# Altium schematic sheet PS_Power.SchDoc
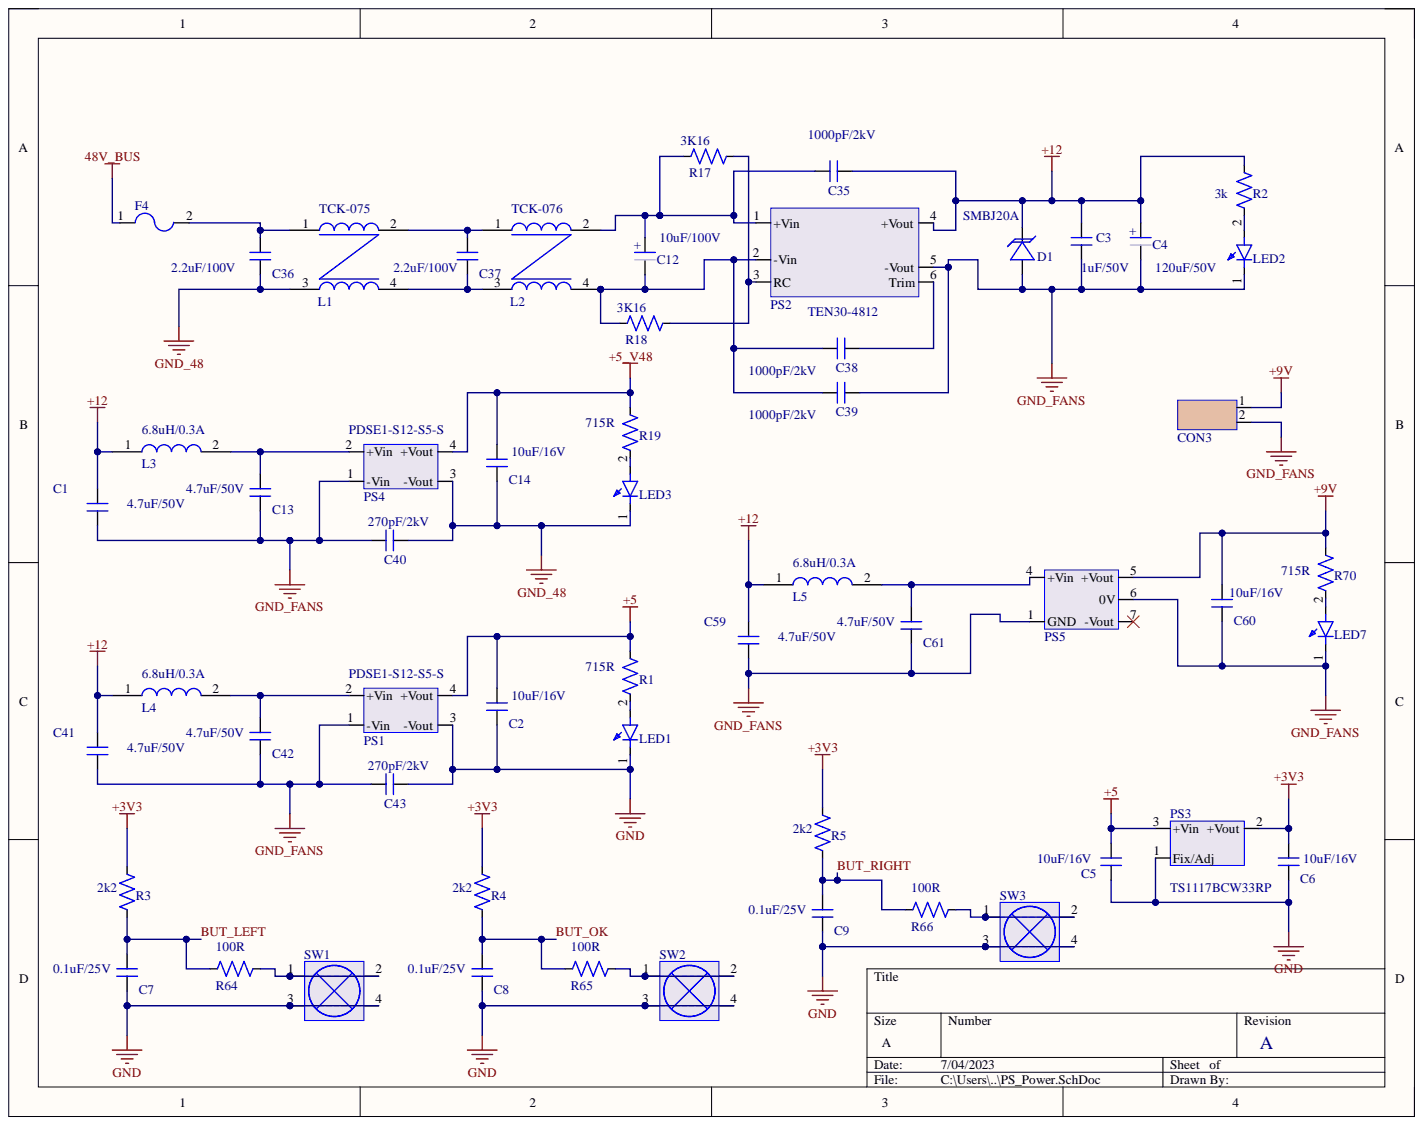
[diagram p0: PS_Power.SchDoc]

## Parts
C1  4.7uF/50V  [C1206]
C2  10uF/16V  [C0805]
C3  1uF/50V  [C0805]
C4  120uF/50V  [Cap_THT_O8mm]
C5  10uF/16V  [C0805]
C6  10uF/16V  [C0805]
C7  0.1uF/25V  [C0402]
C8  0.1uF/25V  [C0402]
C9  0.1uF/25V  [C0402]
C12  10uF/100V  [Cap_THT_O6mm-Lead2.5mm]
C13  4.7uF/50V  [C1206]
C14  10uF/16V  [C0805]
C35  1000pF/2kV  [C1808]
C36  2.2uF/100V  [C1812]
C37  2.2uF/100V  [C1812]
C38  1000pF/2kV  [C1808]
C39  1000pF/2kV  [C1808]
C40  270pF/2kV  [C1206]
C41  4.7uF/50V  [C1206]
C42  4.7uF/50V  [C1206]
C43  270pF/2kV  [C1206]
C59  4.7uF/50V  [C1206]
C60  10uF/16V  [C0805]
C61  4.7uF/50V  [C1206]
CON3  22-28-4024  [Con1x02_2.54]  (value not drawn)
D1  SMBJ20A  [DO-214AA-2]
F4  (no value)  [fuse 1.6A 250V - duplicate]
L1  TCK-075  [TCK-075]
L2  TCK-076  [TCK-075]
L3  6.8uH/0.3A  [L3]
L4  6.8uH/0.3A  [L3]
L5  6.8uH/0.3A  [L0603]
LED1  150080GS75000  [L0805]  (value not drawn)
LED2  150080GS75000  [L0805]  (value not drawn)
LED3  150080GS75000  [L0805]  (value not drawn)
LED7  150080GS75000  [L0805]  (value not drawn)
PS1  PDSE1-S12-S5-S  [SIP-4]
PS2  TEN30-4812  [2''_x_1'']
PS3  TS1117BCW33RP  [SOT-223-3]
PS4  PDSE1-S12-S5-S  [SIP-4]
PS5  PQP3-D12-D9-D  [DIP-5]  (value not drawn)
R1  715R  [R0603]
R2  3k  [R0805]
R3  2k2  [R0402]
R4  2k2  [R0402]
R5  2k2  [R0402]
R17  3K16  [R0402]
R18  3K16  [R0402]
R19  715R  [R0603]
R64  100R  [R0402]
R65  100R  [R0402]
R66  100R  [R0402]
R70  715R  [R0603]
SW1  (no value)  [12mm_SMD]
SW2  (no value)  [12mm_SMD]
SW3  (no value)  [12mm_SMD]

## Nets
48V_BUS: F4.1
+3V3: C6.1 PS3.2 R3.2 R4.2 R5.2
+5: C2.1 C5.1 PS1.4(+Vout) PS3.3 R1.2
+5_V48: C14.1 PS4.4(+Vout) R19.2
+9V: C60.1 CON3.1 PS5.5(+Vout) R70.2
+12: C1.1 C3.1 C4.1 C35.2 C41.1 C59.1 D1.1 L3.1 L4.1 L5.1 PS2.4(+Vout) R2.2
GND: C2.2 C5.2 C6.2 C7.2 C8.2 C9.2 C43.2 LED1.1 PS1.3(-Vout) PS3.1 SW1.3 SW1.4 SW2.3 SW2.4 SW3.3 SW3.4
GND_48: C14.2 C36.2 C40.2 L1.3 LED3.1 PS4.3(-Vout)
GND_FANS: C1.2 C3.2 C4.2 C13.2 C39.2 C40.1 C41.2 C42.2 C43.1 C59.2 C60.2 C61.2 CON3.2 D1.2 LED2.1 LED7.1 PS1.1(-Vin) PS2.5(-Vout) PS4.1(-Vin) PS5.1(GND) PS5.6(0V)
BUT_LEFT: C7.1 R3.1 R64.1
BUT_OK: C8.1 R4.1 R65.1
BUT_RIGHT: C9.1 R5.1 R66.1
NetC12_1: C12.1 C35.1 L2.2 PS2.1(+Vin) R17.1
NetC12_2: C12.2 C38.1 C39.1 L2.4 PS2.2(-Vin) R18.2
NetC13_1: C13.1 L3.2 PS4.2(+Vin)
NetC36_1: C36.1 F4.2 L1.1
NetC37_1: C37.1 L1.2 L2.1
NetC37_2: C37.2 L1.4 L2.3
NetC38_2: C38.2 PS2.6(Trim)
NetC42_1: C42.1 L4.2 PS1.2(+Vin)
NetC61_1: C61.1 L5.2 PS5.4(+Vin)
NetLED1_2: LED1.2 R1.1
NetLED2_2: LED2.2 R2.1
NetLED3_2: LED3.2 R19.1
NetLED7_2: LED7.2 R70.1
NetPS2_3: PS2.3(RC) R17.2 R18.1
NetR64_2: R64.2 SW1.1 SW1.2
NetR65_2: R65.2 SW2.1 SW2.2
NetR66_2: R66.2 SW3.1 SW3.2

Not connected: PS5 7(-Vout)

## Library data of the parts
C1 C13 C41 C42 C59 C61 = CL31B475KBHNFNE: CAP CER 4.7UF 50V X7R 1206; Manufacturer: Samsung; Database Table Name: components; Tolerance: 10%; Capacitance: 4.7uF; Case Code (Imperial): 1206; Case Code (Metric): 3216; Case/Package: 1206; Category: Ceramic Capacitors; Component Kind: Standard; ComponentLink1Description: https://datasheet.ciiva.com/25726/cl31b475kbh; ComponentLink1URL: https://datasheet.ciiva.com/25726/cl31b475kbh; Construction: Flat; Depth: 1.6mm; Dielectric: X7R; Height: 1.6mm; Length: 3.2mm; Library Name: Resistors-Capacitors.DbLib; Manufacturer Part Number: CL31B475KBHNFNE; Max Operating Temperature: 125°C; Min Operating Temperature: -55°C; Mount: Surface Mount; Package Quantity: 1; Packaging: Tape and Reel; Part Number: CL31B475KBHNFNE; Pricing 1: 1=0,30000, 10=0,13500, 50=0,13500, 100=0,1150; Radiation Hardening: No; RoHS: Yes; RoHS Compliant: Yes; Series: CL; Stock 1: 112063; Supplier 1: Mouser; Supplier Part Number 1: 187-CL31B475KBHNFNE; Thickness: 0.071inch; Voltage Rating: 50V; Width: 0.063inch
C2 C5 C6 C14 C60 = CL21A106KOQNNNE: Multilayer Ceramic Capacitors MLCC - SMD/SMT; Manufacturer: Samsung; Capacitance: 10uF; Database Table Name: components; Dielectric: X7R; Tolerance: 10%; Voltage: 16V; Case Code (Imperial): 0805; Case Code (Metric): 2012; Case/Package: 0805; Category: Ceramic Capacitors; Component Kind: Standard; Construction: Flat; Depth: 1.25mm; Height: 1.25mm; Lead Free: Lead Free; Length: 2mm; Library Name: Resistors-Capacitors.DbLib; Manufacturer Part Number: CL21A106KOQNNNE; Max Operating Temperature: 85°C; Min Operating Temperature: -55°C; Mount: Surface Mount; Package Quantity: 1; Packaging: Tape and Reel; Part Number: CL21A106KOQNNNE; Pricing 1: 1=0,10000, 10=0,04100, 50=0,04100, 100=0,0330; Radiation Hardening: No; RoHS: Yes; RoHS Compliant: Yes; Series: CL; Stock 1: 580556; Supplier 1: Mouser; Supplier Part Number 1: 187-CL21A106KOQNNNE; Thickness: 0.055inch; Voltage Rating: 16V; Voltage Rating (DC): 16V; Width: 0.049inch
C3 = CL21B105KBFNNNE: CAP CER 1UF 50V X7R 0805; Manufacturer: Samsung; Database Table Name: components; Tolerance: 10%; Capacitance: 1uF; Case Code (Imperial): 0805; Case Code (Metric): 2012; Case/Package: 0805; Category: Ceramic Capacitors; Component Kind: Standard; Library Name: Resistors-Capacitors.DbLib; Manufacturer Part Number: CL21B105KBFNNNE; Max Operating Temperature: 125°C; Min Operating Temperature: -55°C; Mount: Surface Mount; Package Quantity: 1; Packaging: Tape and Reel; Part Number: CL21B105KBFNNNE; Pricing 1: 1=0,12000, 10=0,08600, 50=0,08600, 100=0,0410; RoHS: Yes; Stock 1: 18533; Supplier 1: Mouser; Supplier Part Number 1: 187-CL21B105KBFNNNE; Voltage Rating: 50V
C4 = 860010674015: Aluminium Electrolytic Capacitor, Wcap-Atg8 S; Manufacturer: Wurth Electronics; Database Table Name: components; Case/Package: Radial; Category: Aluminum Electrolytic Capacitors; Component Kind: Standard; Library Name: Resistors-Capacitors.DbLib; Manufacturer Part Number: 860010674015; Mount: Through Hole; Part Number: 860010674015; Pricing 1: 1=0,31000, 10=0,30800, 50=0,26400, 100=0,2300; RoHS: Yes; Stock 1: 1491; Supplier 1: Mouser; Supplier Part Number 1: 710-860010674015
C7-C9 = C0402C104K3RACTU: Multilayer Ceramic 25V 0.1uF X7R 0402 10%; Manufacturer: Yageo; Database Table Name: components; Case/Package: 0402; Component Kind: Standard; Library Name: Resistors-Capacitors.DbLib; Part Number: C0402C104K3RACTU; Supplier 1: Mouser; Supplier Part Number 1: 80-C0402C104K3R
C12 = ESH106M100AE3AA: Cap Aluminum 10uF 100V 20% (6 X 11mm) Radial; Manufacturer: KEMET; Database Table Name: components; Case/Package: Radial; Category: Aluminum Electrolytic Capacitors; Component Kind: Standard; Library Name: Resistors-Capacitors.DbLib; Manufacturer Part Number: ESH106M100AE3AA; Mount: Through Hole,PCB; Part Number: ESH106M100AE3AA; Pricing 1: 1=0,26000, 10=0,18100, 50=0,12100, 100=0,1030; RoHS: Yes; Stock 1: 18650; Supplier 1: Mouser; Supplier Part Number 1: 80-ESH106M100AE3AA
C35 C38 C39 = GR442QR73D102KW01L: Cap Ceramic 0.001uF 2000V X7R 10% SMD 1808 12; Manufacturer: Murata; Capacitance: 1nF; Database Table Name: components; Voltage: 2kV; Case Code (Imperial): 1808; Case Code (Metric): 4520; Case/Package: 1808; Category: Ceramic Capacitors; Component Kind: Standard; ComponentLink1Description: https://datasheet.ciiva.com/29540/product-295; ComponentLink1URL: https://datasheet.ciiva.com/29540/product-295; ComponentLink2Description: https://datasheet.ciiva.com/11789/0900766b80e; ComponentLink2URL: https://datasheet.ciiva.com/11789/0900766b80e; ComponentLink3Description: https://datasheet.ciiva.com/18183/getdatashee; ComponentLink3URL: https://datasheet.ciiva.com/18183/getdatashee; ComponentLink4Description: https://datasheet.ciiva.com/29540/partnumberi; ComponentLink4URL: https://datasheet.ciiva.com/29540/partnumberi; ComponentLink5Description: https://datasheet.ciiva.com/16497/product-164; ComponentLink5URL: https://datasheet.ciiva.com/16497/product-164; Construction: Flat; Depth: 2mm; Dielectric: X7R; Height: 1.5mm; Lead Free: Lead Free; Length: 4.5mm; Library Name: Resistors-Capacitors.DbLib; Manufacturer Part Number: GR442QR73D102KW01L; Max Operating Temperature: 125°C; Min Operating Temperature: -55°C; Mount: Surface Mount; Package Quantity: 1; Packaging: Tape and Reel; Part Number: GR442QR73D102KW01L; Pricing 1: 1=0,44000, 10=0,27800, 50=0,27800, 100=0,2060; Radiation Hardening: No; Reach SVHC Compliant: Yes; RoHS: Yes; RoHS Compliant: Yes; Series: GR4; Stock 1: 172848; Supplier 1: Mouser; Supplier Part Number 1: 81-GR442QR73D102KW01; Termination: SMD/SMT; Thickness: 0.059inch; Tolerance: 10%; Voltage Rating: 2kV; Voltage Rating (DC): 2kV; Width: 0.079inch
C36 C37 = C4532X7R2A225K230KA: C Series 1812 2.2 uF 100 V ±10 % Tolerance X7; Manufacturer: TDK; Capacitance: 2.2uF; Database Table Name: components; Dielectric: X7R; Tolerance: 10%; Voltage: 100V; Case Code (Imperial): 1812; Case Code (Metric): 4532; Case/Package: 1812; Category: Ceramic Capacitors; Component Kind: Standard; ComponentLink1Description: https://datasheet.ciiva.com/24216/c4532x7r2a2; ComponentLink1URL: https://datasheet.ciiva.com/24216/c4532x7r2a2; ComponentLink2Description: https://datasheet.ciiva.com/11788/0900766b80f; ComponentLink2URL: https://datasheet.ciiva.com/11788/0900766b80f; ComponentLink3Description: https://datasheet.ciiva.com/22725/1913358-227; ComponentLink3URL: https://datasheet.ciiva.com/22725/1913358-227; ComponentLink4Description: https://datasheet.ciiva.com/23803/1796654-238; ComponentLink4URL: https://datasheet.ciiva.com/23803/1796654-238; Construction: Flat; Depth: 3.2mm; Height: 2.3mm; Lead Free: Lead Free; Length: 4.5mm; Library Name: Resistors-Capacitors.DbLib; Manufacturer Part Number: C4532X7R2A225K230KA; Max Operating Temperature: 125°C; Min Operating Temperature: -55°C; Mount: Surface Mount; Number of Pins: 2; Package Quantity: 500; Packaging: Tape and Reel; Part Number: C4532X7R2A225K230KA; Pricing 1: 1=0,86000, 10=0,54600, 50=0,54600, 100=0,4700; Radiation Hardening: No; Reach SVHC Compliant: Yes; Resistor Type: Ceramic; RoHS: Yes; RoHS Compliant: Yes; Series: C; Stock 1: 28251; Supplier 1: Mouser; Supplier Part Number 1: 810-C4532X7R2A225K; Termination: SMD/SMT; Thickness: 0.098inch; Voltage Rating: 100V; Voltage Rating (DC): 100V; Weight: 0.000952oz; Width: 3.2mm
C40 C43 = 1206GC271KAT1A: 1206 HV 270pF Ceramic Multilayer Cap; 2000Vdc; Manufacturer: Kyocera AVX; Database Table Name: components; Dielectric: X7R; Temperature Coefficient: 30ppm/°C; Tolerance: 10%; Voltage: 2kV; Capacitance: 270pF; Case Code (Imperial): 1206; Case Code (Metric): 3216; Case/Package: 1206; Category: Ceramic Capacitors; Component Kind: Standard; ComponentLink1Description: https://datasheet.ciiva.com/11787/0900766b80d; ComponentLink1URL: https://datasheet.ciiva.com/11787/0900766b80d; ComponentLink2Description: https://datasheet.ciiva.com/215/aphvc-215777.; ComponentLink2URL: https://datasheet.ciiva.com/215/aphvc-215777.; ComponentLink3Description: https://datasheet.ciiva.com/11541/aphvc-11541; ComponentLink3URL: https://datasheet.ciiva.com/11541/aphvc-11541; ComponentLink4Description: https://datasheet.ciiva.com/13190/aphvc-13190; ComponentLink4URL: https://datasheet.ciiva.com/13190/aphvc-13190; Construction: Flat; Depth: 1.6mm; Dielectric Material: Ceramic; Height: 1.52mm; Lead Free: Lead Free; Length: 3.2mm; Library Name: Resistors-Capacitors.DbLib; Manufacturer Part Number: 1206GC271KAT1A; Max Operating Temperature: 125°C; Min Operating Temperature: -55°C; Mount: Surface Mount; Number of Pins: 2; Package Quantity: 2000; Packaging: Tape and Reel; Part Number: 1206GC271KAT1A; Pricing 1: 1=0,74000, 10=0,55200, 50=0,55200, 100=0,3780; Radiation Hardening: No; Reach SVHC Compliant: Yes; RoHS: Yes; RoHS Compliant: Yes; Series: -; Stock 1: 4864; Supplier 1: Mouser; Supplier Part Number 1: 581-1206GC271KAT1A; Termination: SMD/SMT; Thickness: 0.06inch; Voltage Rating: 2kV; Voltage Rating (DC): 2kV; Width: 0.063inch
CON3 = 22-28-4024: Breakaway Header; KK 254; Vertical; 2 Circuit; Manufacturer: Molex; Database Table Name: connectors; Category: Headers and Wire Housings; Component Kind: Standard; ComponentLink1Description: https://datasheet.ciiva.com/10088/0022284024-; ComponentLink1URL: https://datasheet.ciiva.com/10088/0022284024-; ComponentLink2Description: https://datasheet.ciiva.com/5153/0022284024-p; ComponentLink2URL: https://datasheet.ciiva.com/5153/0022284024-p; ComponentLink3Description: https://datasheet.ciiva.com/30120/63280022284; ComponentLink3URL: https://datasheet.ciiva.com/30120/63280022284; ComponentLink4Description: https://datasheet.ciiva.com/18451/70090858-18; ComponentLink4URL: https://datasheet.ciiva.com/18451/70090858-18; ComponentLink5Description: https://datasheet.ciiva.com/1433/1856632-1433; ComponentLink5URL: https://datasheet.ciiva.com/1433/1856632-1433; Library Name: Connectors.DbLib; Manufacturer Part Number: 22-28-4024; Part Number: 22-28-4024; Pricing 1: 1=0,22000, 10=0,17700, 50=0,17700, 100=0,1270; RoHS: Yes; Stock 1: 11115; Supplier 1: Mouser; Supplier Part Number 1: 538-22-28-4024
D1 = SMBJ20A: Diode TVS Single Uni-Dir 20V 600W 2-Pin SMB; Manufacturer: Littelfuse; Breakdown Voltage: 22.2V; Clamping Voltage: 32.4V; Database Table Name: components; Diode Type: ZENER; Direction: Unidirectional; Leakage Current: 1uA; Max Breakdown Voltage: 24.5V; Max Surge Current: 18.6A; Number of Channels: 1; Power Line Protection: No; Test Current: 1mA; Case/Package: DO-214AA; Category: TVS Diodes; Clamping Voltage-Max: 32.4V; Component Kind: Standard; ComponentLink1Description: https://datasheet.ciiva.com/46381/607623-4638; ComponentLink1URL: https://datasheet.ciiva.com/46381/607623-4638; ComponentLink2Description: https://datasheet.ciiva.com/331/littelfuse-tv; ComponentLink2URL: https://datasheet.ciiva.com/331/littelfuse-tv; ComponentLink3Description: https://datasheet.ciiva.com/16391/littelfuse-; ComponentLink3URL: https://datasheet.ciiva.com/16391/littelfuse-; ComponentLink4Description: https://datasheet.ciiva.com/331/littelfuse-tv; ComponentLink4URL: https://datasheet.ciiva.com/331/littelfuse-tv; ComponentLink5Description: https://datasheet.ciiva.com/331/littelfuse-tv; ComponentLink5URL: https://datasheet.ciiva.com/331/littelfuse-tv; ComponentLink6Description: https://datasheet.ciiva.com/4509/littelfuse-t; ComponentLink6URL: https://datasheet.ciiva.com/4509/littelfuse-t; Height: 2.44mm; Lead Free: Lead Free; Length: 5.59mm; Library Name: Diodes.DbLib; Manufacturer Part Number: SMBJ20A; Max Operating Temperature: 150°C; Max Power Dissipation: 600W; Min Breakdown Voltage: 22.2V; Min Operating Temperature: -55°C; Mount: Surface Mount; Number of Elements: 1; Number of Pins: 2; Number of Unidirectional Channels: 1; Operating Supply Voltage: 20V; Package Quantity: 3000; Packaging: Tape and Reel; Part Number: SMBJ20A; Peak Pulse Current: 18.6A; Peak Pulse Power: 600W; Polarity: Unidirectional; Power Dissipation: 600W; Pricing 1: 1=0,48510, 10=0,37210, 25=0,36840, 100=0,2633; Radiation Hardening: No; REACH SVHC: No SVHC; Resistor Type: Zener; Reverse Breakdown Voltage: 22.2V; Reverse Standoff Voltage: 20V; RoHS: Yes; RoHS Compliant: Yes; Series: SMBJ; Stock 1: 6334; Supplier 1: Mouser; Supplier Part Number 1: 821-SMBJ20A; Termination: SMD/SMT; Voltage Rating (DC): 20V; Width: 3.94mm
F4 = 3403.0168.11: Fuse Chip Slow Blow Acting 1.6A 250V SMD Sold; Manufacturer: Schurter; Database Table Name: components; Case/Package: SMD/SMT; Category: Fuses; Component Kind: Standard; Library Name: Supplies.DbLib; Manufacturer Part Number: 3403.0168.11; Mount: Surface Mount,PCB; Number of Pins: 2; Part Number: 3403.0168.11; Pricing 1: 1=0,69000, 10=0,69000, 50=0,62200, 100=0,5670; RoHS: Yes; Stock 1: 1214; Supplier 1: Mouser; Supplier Part Number 1: 693-3403.0168.11; Weight: 0.008113oz
L1 = TCK-075: Common Mode Chokes Dual 33.3uH 100kHz 4A 0.00; Manufacturer: Traco Power; Database Table Name: components; Category: Common Mode Chokes; Component Kind: Standard; Library Name: Circuit_Protection.DbLib; Manufacturer Part Number: TCK-075; Max Operating Temperature: 105°C; Min Operating Temperature: -40°C; Mount: Through Hole; Part Number: TCK-075; Pricing 1: 1=6,29000, 10=5,71000, 50=5,14000, 100=4,1900; RoHS: Yes; Stock 1: 90; Supplier 1: Mouser; Supplier Part Number 1: 495-TCK-075
L2 = TCK-076: COMMON MODE CHOKE, 55UH, 5.7A; Product Range:; Manufacturer: Traco Power; Current Rating: 4A; Database Table Name: components; Inductance: 55.23uH; Number of Lines: 2; Category: Common Mode Chokes; Component Kind: Standard; Library Name: Circuit_Protection.DbLib; Manufacturer Part Number: TCK-076; Max Current Rating: 5.7A; Max Operating Temperature: 105°C; Min Operating Temperature: -40°C; Mount: Through Hole; Part Number: TCK-076; Pricing 1: 1=6,29000, 10=5,71000, 50=4,48000, 100=4,4800; RoHS: Yes; Stock 1: 84; Supplier 1: Mouser; Supplier Part Number 1: 495-TCK-076
L3-L5 = MLZ1608M6R8WT000: 1.6mm x 0.8mm x 0.8mm, -55 to +125 degC, 100m; Manufacturer: TDK; Database Table Name: components; Category: Fixed Inductors; Component Kind: Standard; Library Name: Resistors-Capacitors.DbLib; Manufacturer Part Number: MLZ1608M6R8WT000; Part Number: MLZ1608M6R8WT000; Pricing 1: 1=0,14000, 10=0,10300, 50=0,10300, 100=0,0820; RoHS: Yes; Stock 1: 398; Supplier 1: Mouser; Supplier Part Number 1: 810-MLZ1608M6R8WT000
LED1-LED3 LED7 = 150080GS75000: Led, Green, 520 Nm, 3.2 V, 30 Ma, 450 Mcd Roh; Manufacturer: Wurth Electronics; Database Table Name: hmi; Category: LEDs; Component Kind: Standard; Library Name: HMI.DbLib; Manufacturer Part Number: 150080GS75000; Part Number: 150080GS75000; Pricing 1: 1=0,19000, 10=0,18700, 50=0,17600, 100=0,1510; RoHS: Yes; Stock 1: 29367; Supplier 1: Mouser; Supplier Part Number 1: 710-150080GS75000
PS1 PS4 = PDSE1-S12-S5-S: 5 Vdc, 0.2 A, 1 W, 10.8~13.2 Vdc Input Range; Manufacturer: CUI; Database Table Name: components; Category: Board Mount Modules; Component Kind: Standard; Library Name: Supplies.DbLib; Manufacturer Part Number: PDSE1-S12-S5-S; Part Number: PDSE1-S12-S5-S; Pricing 1: 1=2,57000, 10=2,51000, 50=2,29000, 100=2,2900; RoHS: Yes; Stock 1: 231; Supplier 1: Mouser; Supplier Part Number 1: 490-PDSE1-S12-S5-S
PS2 = TEN30-4812: Module DC-DC 48VIN 1-OUT 12V 25A 30W 6-Pin; Manufacturer: Traco Power; Approvals: VDE,TUV,cURus,CQC,PSE,KC; Body Material: Ceramic; Current - Output 1: 2.5A; Current Rating: 1.6A; Database Table Name: components; Efficiency: 91%; Fuse Size: 10.1 X 3mm; Fuse Type: Board Mount (Cartridge Style Excluded); Isolation: Isolated; Isolation Voltage: 1.5kV; Max Input Voltage: 75V; Max Power Dissipation: 1W; Max Voltage Rating (AC): 250V; Max Voltage Rating (DC): 125V; Min Input Voltage: 36V; Nominal Input Voltage: 48V; Number of Outputs: 1; Case/Package: Metal; Component Kind: Standard; ComponentLink1Description: https://datasheet.ciiva.com/41865/1648785-418; ComponentLink1URL: https://datasheet.ciiva.com/41865/1648785-418; ComponentLink2Description: https://datasheet.ciiva.com/26336/157312-da-0; ComponentLink2URL: https://datasheet.ciiva.com/26336/157312-da-0; ComponentLink3Description: https://datasheet.ciiva.com/8006/1763620-8006; ComponentLink3URL: https://datasheet.ciiva.com/8006/1763620-8006; ComponentLink4Description: https://datasheet.ciiva.com/302/typ-umt-250-3; ComponentLink4URL: https://datasheet.ciiva.com/302/typ-umt-250-3; ComponentLink5Description: https://datasheet.ciiva.com/8266/typ-umt-250-; ComponentLink5URL: https://datasheet.ciiva.com/8266/typ-umt-250-; Contact Plating: Tin; Depth: 50.8mm; Height: 10.2mm; Housing Material: Ceramic; Lead Free: Lead Free; Length: 50mm; Library Name: Supplies.DbLib; Manufacturer Part Number: TEN30-4812; Max Input Voltage (DC): 75V; Max Operating Temperature: 85°C; Max Output Current: 2.5A; Max Output Power: 30W; Min Input Voltage (DC): 36V; Min Operating Temperature: -40°C; Mount: Through Hole; Nominal Output Voltage: 12V; Number of Pins: 6; Output Current: 25A; Output Voltage: 12V; Package Quantity: 100; Packaging: Bulk; Part Number: TEN30-4812; Power Dissipation: 1W; Pricing 1: 1=68,12000, 10=62,01000, 50=53,15000, 100=53,; Radiation Hardening: No; Reach SVHC Compliant: Yes; RoHS: Yes; RoHS Compliant: Yes; Series: TEN 30; Stock 1: 9; Supplier 1: Mouser; Supplier Part Number 1: 495-TEN30-4812; Termination: SMD/SMT; Voltage - Output 1: 12V; Voltage Rating: 250V; Voltage Rating (AC): 250V; Voltage Rating (DC): 125V; Weight: 31g; Width: 25.4mm
PS3 = TS1117BCW33RP: LDO Regulator Pos 3.3V 1A 4-Pin(3+Tab) SOT-22; Manufacturer: Taiwan Semiconductor; Accuracy: 2%; Amplifier Type: GENERAL PURPOSE; Common Mode Rejection Ratio: 50dB; Database Table Name: components; Gain Bandwidth Product: 1.5MHz; Input Bias Current: 14nA; Input Offset Voltage (Vos): 1mV; Max Input Voltage: 15V; Max Output Current: 800mA; Max Power Dissipation: 450mW; Max Reset Threshold Voltage: 1.295V; Max Supply Current: 900uA; Max Supply Voltage: 5V; Min Reset Threshold Voltage: 1.245V; Min Supply Voltage: 1.8V; Nominal Supply Current: 103uA; Number of Amplifiers: 1; Number of Voltages Monitored: 2; Operating Supply Current: 116uA; Operating Supply Voltage: 3V; Output Current per Channel: 100mA; Output Type: Fixed; Polarity: Positive; Power Dissipation: 15W; Power Supply Rejection Ratio (PSRR): 75dB; Quiescent Current: 10mA; Reference Voltage: 1.275V; Slew Rate: 0.42V/µs; Threshold Voltage: 1.27V; Voltage Gain: 113dB; Watchdog Timer: No; Case/Package: SOT-223; Category: Uncategorized; Component Kind: Standard; ComponentLink1Description: http://uk.rs-online.com/webdocs/1405/0900766b; ComponentLink1URL: http://uk.rs-online.com/webdocs/1405/0900766b; ComponentLink2Description: http://components.arrow.com/part/dataview/459; ComponentLink2URL: http://components.arrow.com/part/dataview/459; ComponentLink3Description: https://datasheet.ciiva.com/5704/786773-57042; ComponentLink3URL: https://datasheet.ciiva.com/5704/786773-57042; ComponentLink4Description: https://datasheet.ciiva.com/6627/lmv981-n-662; ComponentLink4URL: https://datasheet.ciiva.com/6627/lmv981-n-662; ComponentLink5Description: https://datasheet.ciiva.com/6627/lmv981-n-662; ComponentLink5URL: https://datasheet.ciiva.com/6627/lmv981-n-662; ComponentLink6Description: https://datasheet.ciiva.com/6627/lmv981-n-662; ComponentLink6URL: https://datasheet.ciiva.com/6627/lmv981-n-662; ComponentLink7Description: https://datasheet.ciiva.com/1179/mc34161-d-11; ComponentLink7URL: https://datasheet.ciiva.com/1179/mc34161-d-11; ComponentLink8Description: https://datasheet.ciiva.com/1970/mc34161-d-19; ComponentLink8URL: https://datasheet.ciiva.com/1970/mc34161-d-19; ComponentLink9Description: https://datasheet.ciiva.com/3306/mc34161-d-33; ComponentLink9URL: https://datasheet.ciiva.com/3306/mc34161-d-33; ComponentLink10Description: https://datasheet.ciiva.com/8132/mc34161-d-81; ComponentLink10URL: https://datasheet.ciiva.com/8132/mc34161-d-81; ComponentLink11Description: https://datasheet.ciiva.com/1970/mc34161-d-19; ComponentLink11URL: https://datasheet.ciiva.com/1970/mc34161-d-19; ComponentLink12Description: https://datasheet.ciiva.com/1970/mc34161-d-19; ComponentLink12URL: https://datasheet.ciiva.com/1970/mc34161-d-19; Halogen Free: Halogen Free; Height: 1.8mm; Lead Free: Lead Free; Length: 6.85mm; Library Name: ICs-smd.DbLib; Manufacturer Part Number: TS1117BCW33RP; Max Operating Temperature: 125°C; Min Operating Temperature: 0°C; Mount: Surface Mount; Number of Circuits: 1; Number of Elements: 1; Number of Outputs: 1; Output Current: 1A; Output Voltage: 3.3V; Output Voltage Accuracy: 2%; Package Quantity: 1000; Packaging: Tape and Reel; Part Number: TS1117BCW33RP; Power Rating: 15W; Radiation Hardening: No; Reach SVHC Compliant: Yes; RoHS: Yes; RoHS Compliant: Yes; Series: -; Supplier 1: Mouser; Supplier Part Number 1: 821-TS1117BCW33RPG; Technology: BICMOS; Type: Multi-Voltage Supervisor; Unity Gain Bandwidth Product: 1.5MHz; Width: 3.75mm
PS5 = PQP3-D12-D9-D: 9/-9 Vdc, 0.167/-0.167 A, 3 W, 9~18 Vdc Input; Manufacturer: CUI; Database Table Name: components; Category: Board Mount Modules; Component Kind: Standard; Library Name: Supplies.DbLib; Manufacturer Part Number: PQP3-D12-D9-D; Part Number: PQP3-D12-D9-D; Pricing 1: 1=9,27, 10=9,13, 50=8,44, 100=8,44, 1000=7,46; RoHS: Yes; Stock 1: 23; Supplier 1: Mouser; Supplier Part Number 1: 490-PQP3-D12-D9-D
R1 R19 R70 = RT0603FRE07715RL: Res Thin Film 0603 715 Ohm 1% 1/10W ±50ppm/°C; Manufacturer: Yageo; Database Table Name: components; Max Power Dissipation: 100mW; Voltage Rating: 75V; Voltage Rating (DC): 50V; Case Code (Imperial): 0603; Case Code (Metric): 1608; Case/Package: 0603; Category: Chip SMD Resistors; Component Kind: Standard; ComponentLink1Description: https://datasheet.ciiva.com/2559/pyu-rt-1-to-; ComponentLink1URL: https://datasheet.ciiva.com/2559/pyu-rt-1-to-; ComponentLink2Description: https://datasheet.ciiva.com/12702/pyu-rt-1-to; ComponentLink2URL: https://datasheet.ciiva.com/12702/pyu-rt-1-to; Contact Plating: Tin,Matte; Height: 0.022inch; Lead Free: Lead Free; Length: 0.063inch; Library Name: Resistors-Capacitors.DbLib; Manufacturer Part Number: RT0603FRE07715RL; Max Operating Temperature: 125°C; Min Operating Temperature: -55°C; Number of Terminations: 2; Package Quantity: 5000; Packaging: Tape and Reel; Part Number: RT0603FRE07715RL; Power Rating: 100mW; Pricing 1: 1=0,10000, 10=0,05700, 50=0,05700, 100=0,0230; Radiation Hardening: No; Resistance: 715R; Resistor Type: Thin Film; RoHS: Yes; RoHS Compliant: Yes; Series: RT; Stock 1: 8890; Supplier 1: Mouser; Supplier Part Number 1: 603-RT0603FRE07715RL; Temperature Coefficient: 50ppm/°C; Termination: SMD/SMT; Tolerance: 1%; Width: 0.031inch
R2 = RT0805FRE073KL: Res Thin Film 0805 3K Ohm 1% 1/8W ±50ppm/°C M; Manufacturer: Yageo; Database Table Name: components; Max Power Dissipation: 125mW; Resistance: 3kR; Voltage Rating (DC): 150V; Case/Package: 0805; Category: Chip SMD Resistors; Component Kind: Standard; ComponentLink1Description: http://www.yageo.com/documents/recent/PYu-RT_; ComponentLink1URL: http://www.yageo.com/documents/recent/PYu-RT_; ComponentLink2Description: http://www.yageo.com/documents/recent/PYu-RT_; ComponentLink2URL: http://www.yageo.com/documents/recent/PYu-RT_; Construction: Rectangular; Contact Plating: Tin,Matte; Depth: 1.25mm; Lead Free: Lead Free; Length: 2mm; Library Name: Resistors-Capacitors.DbLib; Manufacturer Part Number: RT0805FRE073KL; Max Operating Temperature: 125°C; Military Standard: Not; Min Operating Temperature: -55°C; Number of Terminations: 2; Packaging: Tape and Reel; Part Number: RT0805FRE073KL; Pricing 1: 1=0,10000, 10=0,08400, 50=0,08400, 100=0,0330; Radiation Hardening: No; Resistor Type: Thin Film; RoHS: Yes; RoHS Compliant: Yes; Series: RT; Stock 1: 4566; Supplier 1: Mouser; Supplier Part Number 1: 603-RT0805FRE073KL; Temperature Coefficient: 50ppm/°C; Tolerance: 1%; Width: 1.25mm
R3-R5 = RC0402JR-132K2L: Res Thick Film 0402 2.2K Ohm 5% 1/16W ±100ppm; Manufacturer: Yageo; Database Table Name: components; Resistance: 2.2kR; Temperature Coefficient: 100ppm/°C; Case/Package: 0402; Category: Chip SMD Resistors; Component Kind: Standard; ComponentLink1Description: https://datasheet.ciiva.com/8894/pyu-rc0402-5; ComponentLink1URL: https://datasheet.ciiva.com/8894/pyu-rc0402-5; Construction: Rectangular; Contact Plating: Tin,Matte; Depth: 0.5mm; Length: 1mm; Library Name: Resistors-Capacitors.DbLib; Manufacturer Part Number: RC0402JR-132K2L; Max Operating Temperature: 155°C; Military Standard: Not; Min Operating Temperature: -55°C; Packaging: Tape and Reel; Part Number: RC0402JR-132K2L; Pricing 1: 1=0,10000, 10=0,01000, 50=0,01000, 100=0,0040; Radiation Hardening: No; Resistor Type: Thick Film; RoHS: Yes; RoHS Compliant: Yes; Stock 1: 75332; Supplier 1: Mouser; Supplier Part Number 1: 603-RC0402JR-132K2L; Tolerance: 5%
R17 R18 = MCT06030C3161FP500: Res Thin Film 0603 3.16K Ohm 1% 1/10W ±50ppm/; Manufacturer: Vishay BCcomponents; Database Table Name: components; Max Power Dissipation: 125mW; Power Rating: 100mW; Resistance: 3.16kR; Temperature Coefficient: 50ppm/°C; Voltage Rating: 75V; Case Code (Imperial): 0603; Case Code (Metric): 1608; Case/Package: 0603; Category: Chip SMD Resistors; Component Kind: Standard; ComponentLink1Description: https://datasheet.ciiva.com/9112/mc-pro-91126; ComponentLink1URL: https://datasheet.ciiva.com/9112/mc-pro-91126; Construction: Rectangular; Contact Plating: Tin; Depth: 0.85mm; Features: Anti-Sulfur; Lead Free: Lead Free; Length: 1.55mm; Library Name: Resistors-Capacitors.DbLib; Manufacturer Part Number: MCT06030C3161FP500; Max Operating Temperature: 125°C; Military Standard: Not; Min Operating Temperature: -55°C; Mount: Surface Mount; Number of Terminations: 2; Packaging: Tape and Reel; Part Number: MCT06030C3161FP500; Pricing 1: 10=0,12800, 25=0,10200, 50=0,07500, 100=0,049; Radiation Hardening: No; REACH SVHC: Unknown; Resistance Tolerance: 1%; Resistor Type: Thin Film; RoHS: Yes; RoHS Compliant: Yes; Series: MCT - Professional; Stock 1: 202; Supplier 1: Mouser; Supplier Part Number 1: 594-MCT06030C3161FP5; Tolerance: 1%; Voltage Rating (DC): 75V; Width: 850um
R64-R66 = RT0402FRE07100RL: Res Thin Film 0402 100 Ohm 1% 0.063W(1/16W) ±; Manufacturer: Yageo; Database Table Name: components; Max Power Dissipation: 63mW; Power Rating: 62.5mW; Temperature Coefficient: 50ppm/°C; Voltage Rating: 50V; Case Code (Imperial): 0402; Case Code (Metric): 1005; Case/Package: 0402; Category: Chip SMD Resistors; Component Kind: Standard; ComponentLink1Description: https://datasheet.ciiva.com/12836/pyu-rt-1-to; ComponentLink1URL: https://datasheet.ciiva.com/12836/pyu-rt-1-to; ComponentLink2Description: https://datasheet.ciiva.com/8887/pyu-rt-1-to-; ComponentLink2URL: https://datasheet.ciiva.com/8887/pyu-rt-1-to-; Construction: Rectangular; Contact Plating: Tin,Matte; Depth: 0.5mm; Features: Moisture Resistant; Height: 0.014inch; Lead Free: Lead Free; Length: 1mm; Library Name: Resistors-Capacitors.DbLib; Manufacturer Part Number: RT0402FRE07100RL; Max Operating Temperature: 125°C; Military Standard: Not; Min Operating Temperature: -55°C; Number of Terminations: 2; Package Quantity: 10000; Packaging: Tape and Reel; Part Number: RT0402FRE07100RL; Pricing 1: 1=0,10000, 10=0,07000, 50=0,07000, 100=0,0290; Radiation Hardening: No; Resistance: 100R; Resistor Type: Thin Film; RoHS: Yes; RoHS Compliant: Yes; Series: RT; Size Code: 0402; Stock 1: 800109; Supplier 1: Mouser; Supplier Part Number 1: 603-RT0402FRE07100RL; Termination: SMD/SMT; Tolerance: 1%; Voltage Rating (DC): 50V; Weight: 2.3E-05oz; Width: 0.02inch
SW1-SW3 = PTS125SM43SMTR21M LFS: Tact Switch 12X12MM Vertical Typ; Manufacturer: Wurth Electronics; Manufacturer Part Number: 430162085826; Database Table Name: hmi; Dominant Wavelength: 520nm; Forward Current: 30mA; Forward Voltage: 3.2V; Lens Color: Colorless; Lens Size: 2.4mm; Lens Style: Clear,Rectangular; Lens Transparency: Clear; Luminous Intensity: 450mcd; Test Current: 20mA; Viewing Angle: 140°; Case Code (Imperial): 0805; Case Code (Metric): 2012; Case/Package: 0805; Category: Tactile Switches; Color: Green; Component Kind: Standard; ComponentLink1Description: https://katalog.we-online.com/em/datasheet/43; ComponentLink1URL: https://katalog.we-online.com/em/datasheet/43; ComponentLink2Description: https://datasheet.ciiva.com/5761/1717943-5761; ComponentLink2URL: https://datasheet.ciiva.com/5761/1717943-5761; ComponentLink3Description: https://datasheet.ciiva.com/29563/150080gs750; ComponentLink3URL: https://datasheet.ciiva.com/29563/150080gs750; ComponentLink4Description: https://datasheet.ciiva.com/29279/759434-da-0; ComponentLink4URL: https://datasheet.ciiva.com/29279/759434-da-0; ComponentLink5Description: https://datasheet.ciiva.com/23813/150141rv731; ComponentLink5URL: https://datasheet.ciiva.com/23813/150141rv731; ComponentLink6Description: https://datasheet.ciiva.com/29278/759469-da-0; ComponentLink6URL: https://datasheet.ciiva.com/29278/759469-da-0; ComponentLink7Description: https://datasheet.ciiva.com/22653/1911489-226; ComponentLink7URL: https://datasheet.ciiva.com/22653/1911489-226; Height: 0.7mm; Length: 2mm; Library Name: HMI.DbLib; Max Operating Temperature: 85°C; Min Operating Temperature: -40°C; Mount: Surface Mount; Number of Pins: 4; Package Quantity: 4000; Packaging: Cut Tape; Part Number: PTS125SM43SMTR21M LFS; Pricing 1: 1=0,54000, 10=0,51800, 50=0,44700, 100=0,3910; REACH SVHC: Unknown; RoHS: No; RoHS Compliant: Yes; Series: WL-SBTW; Stock 1: 268; Supplier 1: Mouser; Supplier Part Number 1: 710-430162085826; Wavelength: 520nm; Width: 1.25mm
